# Altium schematic sheet PORTS.SchDoc
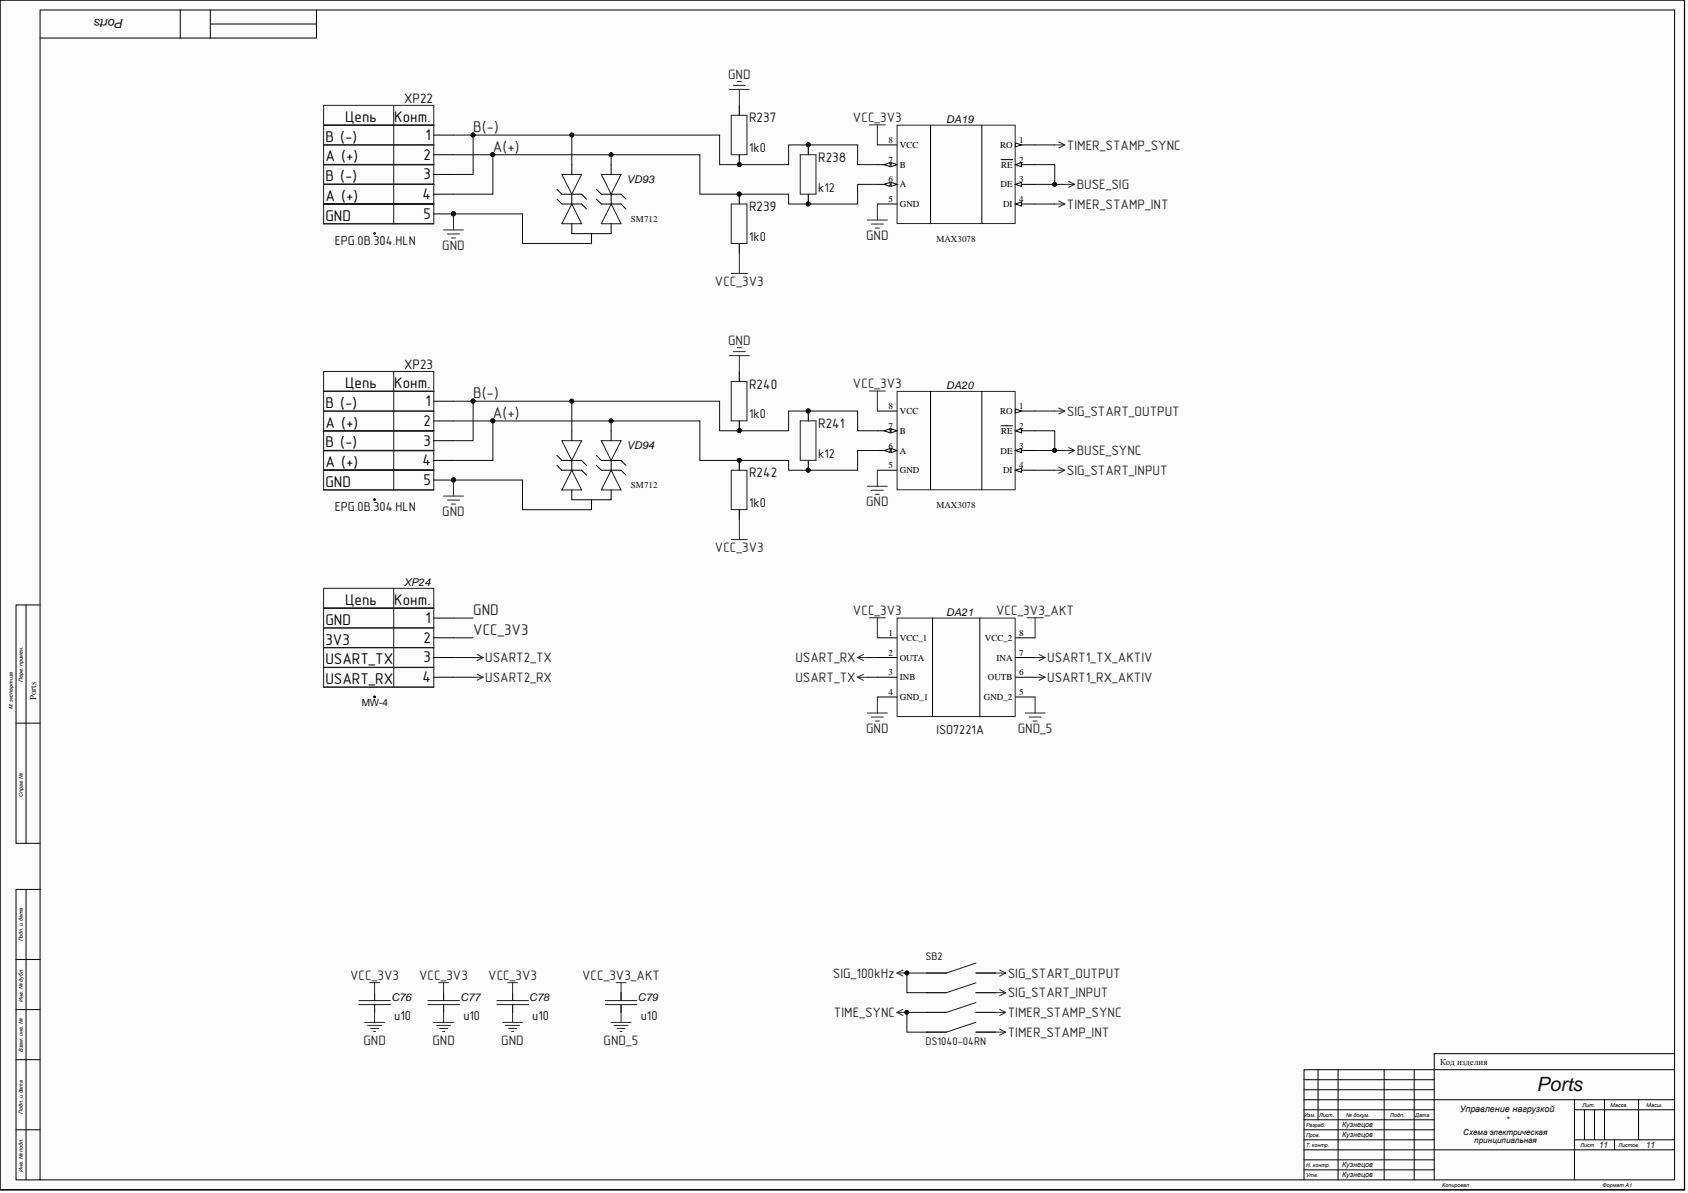
[diagram p0: PORTS.SchDoc]

## Parts
C76  u10
C77  u10
C78  u10
C79  u10
DA19  MAX3078  [SO-8]
DA20  MAX3078  [SO-8]
DA21  ISO7221A  [SO-8]
R237  1k0  [0805]
R238  k12  [0805]
R239  1k0  [0805]
R240  1k0  [0805]
R241  k12  [0805]
R242  1k0  [0805]
SB2  DS1040-04RN  [DS1040-04RN]
VD93  SM712  [SOT23]
VD94  SM712  [SOT23]
XP22  EPG.0B.304.HLN  [LEMO_EPG.0B.304.HLN]
XP23  EPG.0B.304.HLN  [LEMO_EPG.0B.304.HLN]
XP24  MW-4  [MW-4]

## Nets
BUSE_SIG: DA19.2(RE) DA19.3(DE)
BUSE_SYNC: DA20.2(RE) DA20.3(DE)
GND_5: C79.1 DA21.5(GND_2)
SIG_100kHz: SB2.1 SB2.3
SIG_START_INPUT: DA20.4(DI) SB2.4
SIG_START_OUTPUT: DA20.1(RO) SB2.2
TIME_SYNC: SB2.5 SB2.7
TIMER_STAMP_INT: DA19.4(DI) SB2.8
TIMER_STAMP_SYNC: DA19.1(RO) SB2.6
USART1_RX_AKTIV: DA21.6(OUTB)
USART1_TX_AKTIV: DA21.7(INA)
USART2_RX: XP24.4
USART2_TX: XP24.3
USART_RX: DA21.2(OUTA)
USART_TX: DA21.3(INB)
VCC_3V3_AKT: C79.2 DA21.8(VCC_2)
A(+): DA19.6(A) DA20.6(A) R238.2 R239.1 R241.2 R242.1 VD93.1 VD94.1 XP22.2 XP22.4 XP23.2 XP23.4
B(-): DA19.7(B) DA20.7(B) R237.2 R238.1 R240.2 R241.1 VD93.2 VD94.2 XP22.1 XP22.3 XP23.1 XP23.3
GND: C76.1 C77.1 C78.1 DA19.5(GND) DA20.5(GND) DA21.4(GND_1) R237.1 R240.1 VD93.3 VD94.3 XP22.5 XP23.5 XP24.1
VCC_3V3: C76.2 C77.2 C78.2 DA19.8(VCC) DA20.8(VCC) DA21.1(VCC_1) R239.2 R242.2 XP24.2

## Library data of the parts
C76-C79 = (no symbol name): Capacitor; Power\Voltage: 25V; TKE: X5R; Tolerance: 10%; Корпус: 0805; Component Kind: Standard; ComponentLink1Description: Параметры; ComponentLink2Description: Сайт производителя; Value: u10; Author: Eleman; Library Name: eleman_capacitor.schlib
DA19 DA20 = MAX3078EASA: +3V-Powered, Multichannel RS-485 Drivers/Transceiver; ManufacturerPartNumber: MAX3078EASA+; Power\Voltage: 3 V ~ 3.6 V; Component Kind: Standard; ComponentLink1Description: Параметры; ComponentLink2Description: Сайт производителя; Корпус: SO-8; Author: Eleman; Library Name: Eleman_Driver.SchLib
DA21 = ISO7221A: Digital Isolators; Manufacturer: Texas Instruments; ManufacturerPartNumber: ISO7221AQDRQ1; Power\Voltage: 3 V ~ 5.5 V; Value: 1Mbps; Корпус: 8-SOIC; Component Kind: Standard; ComponentLink1Description: Параметры; ComponentLink2Description: Сайт производителя; Supplier 1: Farnell; Supplier Part Number 1: 2411626; Author: Eleman; Library Name: Eleman_Driver.SchLib
R237-R242 = R0805: Импортный постоянный углеродистый резистор; Manufacturer: Vishay Dale; Power\Voltage: 0.125W; Tolerance: 5%; Component Kind: Standard; ComponentLink1Description: Параметры; ComponentLink2Description: Сайт производителя; ManufacturerPartNumber: R0805; Корпус: SMD 0805; Author: Eleman; Library Name: Eleman_Resistor.SchLib
  R237 R239 R240 R242: Value: 1k0
  R238 R241: Value: k12
SB2 = DS1040-04RN: Переключатель однополюсный многопозиционный; Component Kind: Standard; ComponentLink1Description: Параметры; ComponentLink2Description: Сайт производителя; ManufacturerPartNumber: DS1040-04RN; Author: Eleman; Library Name: Eleman_Discret.SchLib
VD93 VD94 = SM712-02: Диодная сборка TVS; ManufacturerPartNumber: SM712-02HTG; Power\Voltage: 12V  19A; Корпус: SOT-23-3; Component Kind: Standard; ComponentLink1Description: Параметры; ComponentLink2Description: Сайт производителя; Author: Eleman; Library Name: Eleman_Diods.SchLib
XP22 XP23 = EPG.0B.304.HLN: Разъем; Manufacturer: LEMO; Корпус: 4 pin; Component Kind: Standard; ComponentLink1Description: Параметры; ComponentLink2Description: Сайт производителя; ManufacturerPartNumber: EPG.0B.304.HLN; Author: Eleman; ComponentLink1URL: C:\Demo\Datasheet; Library Name: Eleman_Connector.SchLib
XP24 = MW-4: Разьём вилка на плату  2mm; Rem: 4 pin; Component Kind: Standard; ComponentLink1Description: Параметры; ComponentLink2Description: Сайт производителя; ManufacturerPartNumber: MW-4; Author: Eleman; ComponentLink1URL: C:\Demo\Datasheet; Library Name: Eleman_Connector.SchLib
